# Altium schematic sheet Sheet1.SchDoc
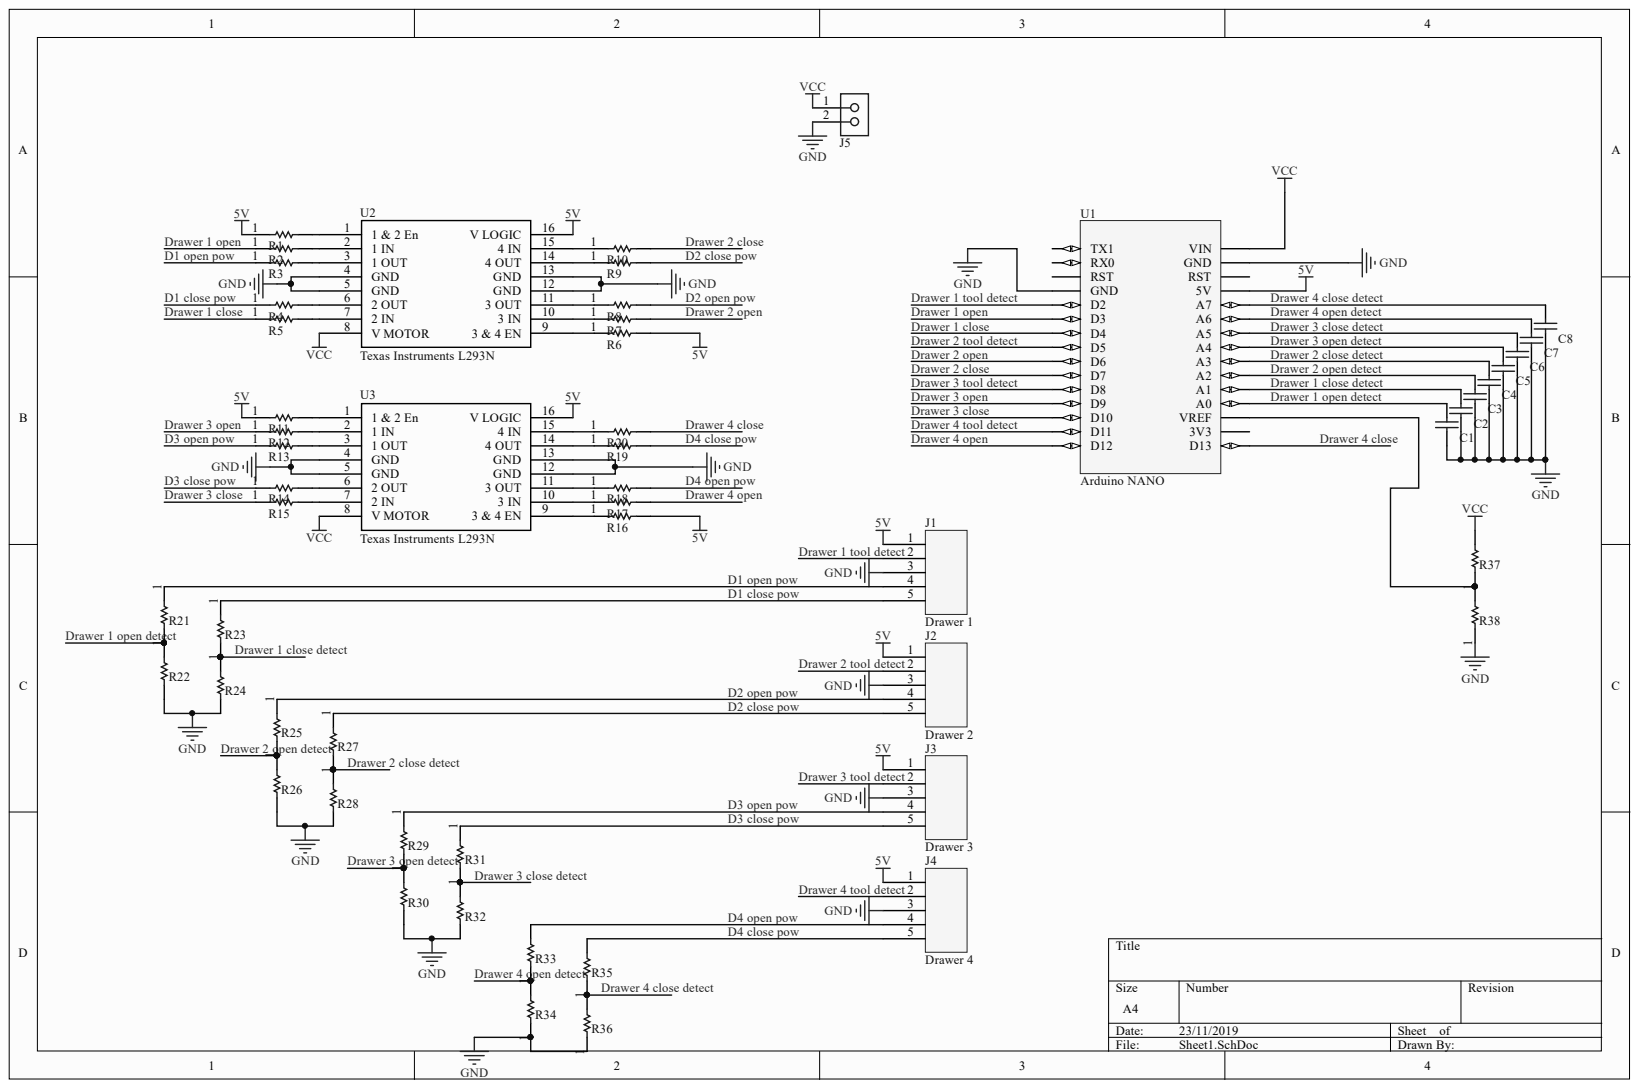
[diagram p0: Sheet1.SchDoc]

## Parts
C1  Vishay AY2331K29Y5SS63L7  [PCB-lydkv1w9v87crgfrhs0c-1]  (value not drawn)
C2  Vishay AY2331K29Y5SS63L7  [PCB-lydkv1w9v87crgfrhs0c-1]  (value not drawn)
C3  Vishay AY2331K29Y5SS63L7  [PCB-lydkv1w9v87crgfrhs0c-1]  (value not drawn)
C4  Vishay AY2331K29Y5SS63L7  [PCB-lydkv1w9v87crgfrhs0c-1]  (value not drawn)
C5  Vishay AY2331K29Y5SS63L7  [PCB-lydkv1w9v87crgfrhs0c-1]  (value not drawn)
C6  Vishay AY2331K29Y5SS63L7  [PCB-lydkv1w9v87crgfrhs0c-1]  (value not drawn)
C7  Vishay AY2331K29Y5SS63L7  [PCB-lydkv1w9v87crgfrhs0c-1]  (value not drawn)
C8  Vishay AY2331K29Y5SS63L7  [PCB-lydkv1w9v87crgfrhs0c-1]  (value not drawn)
J1  Drawer 1  [PCB-ti1mzjrwa86pocq8m1of-1]
J2  Drawer 2  [PCB-ti1mzjrwa86pocq8m1of-1]
J3  Drawer 3  [PCB-ti1mzjrwa86pocq8m1of-1]
J4  Drawer 4  [PCB-ti1mzjrwa86pocq8m1of-1]
J5  Phoenix Contact 1729018 - PWR & AudioFinal  [PCB-kiok1jlp9o9fcwf1wwvf-1]  (value not drawn)
R1  Res1  [Narrow_version]  (value not drawn)
R2  Res1  [Narrow_version]  (value not drawn)
R3  Res1  [Narrow_version]  (value not drawn)
R4  Res1  [Narrow_version]  (value not drawn)
R5  Res1  [Narrow_version]  (value not drawn)
R6  Res1  [Narrow_version]  (value not drawn)
R7  Res1  [Narrow_version]  (value not drawn)
R8  Res1  [Narrow_version]  (value not drawn)
R9  Res1  [Narrow_version]  (value not drawn)
R10  Res1  [Narrow_version]  (value not drawn)
R11  Res1  [Narrow_version]  (value not drawn)
R12  Res1  [Narrow_version]  (value not drawn)
R13  Res1  [Narrow_version]  (value not drawn)
R14  Res1  [Narrow_version]  (value not drawn)
R15  Res1  [Narrow_version]  (value not drawn)
R16  Res1  [Narrow_version]  (value not drawn)
R17  Res1  [Narrow_version]  (value not drawn)
R18  Res1  [Narrow_version]  (value not drawn)
R19  Res1  [Narrow_version]  (value not drawn)
R20  Res1  [Narrow_version]  (value not drawn)
R21  Res1  [Narrow_version]  (value not drawn)
R22  Res1  [Narrow_version]  (value not drawn)
R23  Res1  [Narrow_version]  (value not drawn)
R24  Res1  [Narrow_version]  (value not drawn)
R25  Res1  [Narrow_version]  (value not drawn)
R26  Res1  [Narrow_version]  (value not drawn)
R27  Res1  [Narrow_version]  (value not drawn)
R28  Res1  [Narrow_version]  (value not drawn)
R29  Res1  [Narrow_version]  (value not drawn)
R30  Res1  [Narrow_version]  (value not drawn)
R31  Res1  [Narrow_version]  (value not drawn)
R32  Res1  [Narrow_version]  (value not drawn)
R33  Res1  [Narrow_version]  (value not drawn)
R34  Res1  [Narrow_version]  (value not drawn)
R35  Res1  [Narrow_version]  (value not drawn)
R36  Res1  [Narrow_version]  (value not drawn)
R37  Res1  [Narrow_version]  (value not drawn)
R38  Res1  [Narrow_version]  (value not drawn)
U1  Arduino NANO  [PCB-kcq4ssidua0wf3ce18dn-1]
U2  Texas Instruments L293N  Manufacturer Part Number: L293N  [PCB-cvul4j7exca83ddjrita-1]
U3  Texas Instruments L293N  Manufacturer Part Number: L293N  [PCB-cvul4j7exca83ddjrita-1]

## Nets
5V: J1.1 J2.1 J3.1 J4.1 R1.1 R6.2 R11.1 R16.2 U1.27(5V) U2.16(V_LOGIC) U3.16(V_LOGIC)
GND: C1.1 C2.1 C3.1 C4.1 C5.1 C6.1 C7.1 C8.1 J1.3 J2.3 J3.3 J4.3 J5.2 R22.2 R24.2 R26.2 R28.2 R30.2 R32.2 R34.1 R36.1 R38.1 U1.4(GND) U1.29(GND) U2.4(GND) U2.5(GND) U2.12(GND) U2.13(GND) U3.4(GND) U3.5(GND) U3.12(GND) U3.13(GND)
VCC: J5.1 R37.2 U1.30(VIN) U2.8(V_MOTOR) U3.8(V_MOTOR)
D1 CLOSE POW: J1.5 R4.1 R23.1
D1 OPEN POW: J1.4 R3.1 R21.1
D2 CLOSE POW: J2.5 R9.2 R27.1
D2 OPEN POW: J2.4 R8.2 R25.1
D3 CLOSE POW: J3.5 R14.1 R31.1
D3 OPEN POW: J3.4 R13.1 R29.1
D4 CLOSE POW: J4.5 R19.2 R35.2
D4 OPEN POW: J4.4 R18.2 R33.2
DRAWER 1 CLOSE: R5.1 U1.7(D4)
DRAWER 1 CLOSE DETECT: C2.2 R23.2 R24.1 U1.20(A1)
DRAWER 1 OPEN: R2.1 U1.6(D3)
DRAWER 1 OPEN DETECT: C1.2 R21.2 R22.1 U1.19(A0)
DRAWER 1 TOOL DETECT: J1.2 U1.5(D2)
DRAWER 2 CLOSE: R10.2 U1.10(D7)
DRAWER 2 CLOSE DETECT: C4.2 R27.2 R28.1 U1.22(A3)
DRAWER 2 OPEN: R7.2 U1.9(D6)
DRAWER 2 OPEN DETECT: C3.2 R25.2 R26.1 U1.21(A2)
DRAWER 2 TOOL DETECT: J2.2 U1.8(D5)
DRAWER 3 CLOSE: R15.1 U1.13(D10)
DRAWER 3 CLOSE DETECT: C6.2 R31.2 R32.1 U1.24(A5)
DRAWER 3 OPEN: R12.1 U1.12(D9)
DRAWER 3 OPEN DETECT: C5.2 R29.2 R30.1 U1.23(A4)
DRAWER 3 TOOL DETECT: J3.2 U1.11(D8)
DRAWER 4 CLOSE: R20.2 U1.16(D13)
DRAWER 4 CLOSE DETECT: C8.2 R35.1 R36.2 U1.26(A7)
DRAWER 4 OPEN: R17.2 U1.15(D12)
DRAWER 4 OPEN DETECT: C7.2 R33.1 R34.2 U1.25(A6)
DRAWER 4 TOOL DETECT: J4.2 U1.14(D11)
NetR1_2: R1.2 U2.1(1_&_2_En)
NetR2_2: R2.2 U2.2(1_IN)
NetR3_2: R3.2 U2.3(1_OUT)
NetR4_2: R4.2 U2.6(2_OUT)
NetR5_2: R5.2 U2.7(2_IN)
NetR6_1: R6.1 U2.9(3_&_4_EN)
NetR7_1: R7.1 U2.10(3_IN)
NetR8_1: R8.1 U2.11(3_OUT)
NetR9_1: R9.1 U2.14(4_OUT)
NetR10_1: R10.1 U2.15(4_IN)
NetR11_2: R11.2 U3.1(1_&_2_En)
NetR12_2: R12.2 U3.2(1_IN)
NetR13_2: R13.2 U3.3(1_OUT)
NetR14_2: R14.2 U3.6(2_OUT)
NetR15_2: R15.2 U3.7(2_IN)
NetR16_1: R16.1 U3.9(3_&_4_EN)
NetR17_1: R17.1 U3.10(3_IN)
NetR18_1: R18.1 U3.11(3_OUT)
NetR19_1: R19.1 U3.14(4_OUT)
NetR20_1: R20.1 U3.15(4_IN)
NetR37_1: R37.1 R38.2 U1.18(VREF)

Not connected: U1 1(TX1) 2(RX0) 3(RST) 17(3V3) 28(RST)

## Library data of the parts
C1-C8 = CMP-9cc95711bb34430e-2: Cap Ceramic Suppression X1/Y2 330pF 440VAC/300VAC Y5S 10% (7.5 X 5mm) Radial 7.5mm 125C Automotive Bulk; Voltage Rating (AC): 440 V; Capacitance: 330 pF; Capacitance Tolerance: ±10%; Component Kind: Standard; Dielectric Characteristic: Y5S; Library Name: CommunityVault; Manufacturer Part Number: AY2331K29Y5SS63L7; Mounting Style: Through Hole; OctopartID: 9cc95711bb34430e; Octopart URL: https://octopart.com/ay2331k29y5ss63l7-vishay-47317659; Operating Temperature: -55.0 °C (min); Packaging: Cut Tape (CT); REACH SVHC Compliance: No SVHC; RoHS: Compliant
J1-J4 = CMP-44fb8f34c7a39b3c-12: Screw terminal 1.31 mm² Number of pins 5 282836-5 TE Connectivity Green 1 pc(s); ELV Compliant: Compliant; Pin Pitch: 5.00 mm; Voltage Rating (AC): 250 V; Wire Gauge: 15.0 AWG; Component Kind: Standard; Contact Material: Brass; Contact Plating: Tin; Housing Material: Nylon 6/6; Insulation Material: Nylon; Library Name: CommunityVault; Lifecycle Status: Active; Manufacturer Part Number: 282836-5; Mounting Style: PC Board, PCB; Octopart URL: http://octopart.com/282836-5-te+connectivity-46507582; Orientation: Right Angle; Packaging: Bulk; REACH SVHC Compliance: No SVHC; RoHS: Compliant
J5 = CMP-7ad9b3d37ed8a51e-5: Block, Terminal; 13.5 A; 250 V; 5 mm; 26 to 16 AWG; 2; Screw; Solder; 4 kV; PA; Component Kind: Standard; Library Name: CommunityVault
R1-R38 = CMP-85bf4d852c635a78-2: Through Hole Carbon Film Resistor, CFR Series, 8.2 kohm, 330 mW, - 5%, 250 V, Axial Leaded; Power Rating: 330 mW; Component Kind: Standard; Library Name: CommunityVault; Mounting Style: Axial; REACH SVHC Compliance: No SVHC
U1 = CMP-40a988ff651f9f6e-14: Component Kind: Standard; Description: arduino nano; Library Name: CommunityVault
U2 U3 = CMP-3de1f4f98920e226-1: Quadruple Half-H Drivers 16-PDIP 0 to 70; Drivers Per Package: 4; Lead-Free Status: Lead Free; Output Current: 2.00 A, 1.00 A; Output Voltage: 36.0 V, 39.0 V; Supply Voltage (DC): 4.50 V (min), 36.0 V (max); Case/Package: PDIP; Component Kind: Standard; Library Name: CommunityVault; Lifecycle Status: Obsolete; Mounting Style: Through Hole; Number of Outputs: 4; Number of Pins: 16; OctopartID: 3de1f4f98920e226; Octopart URL: https://octopart.com/l293n-texas+instruments-1216935; Operating Temperature: 0.00 °C to 70.0 °C; Packaging: Tube; REACH SVHC Compliance: No SVHC; RoHS: Compliant
